# Altium schematic sheet 03_USB_TO_UART.SchDoc
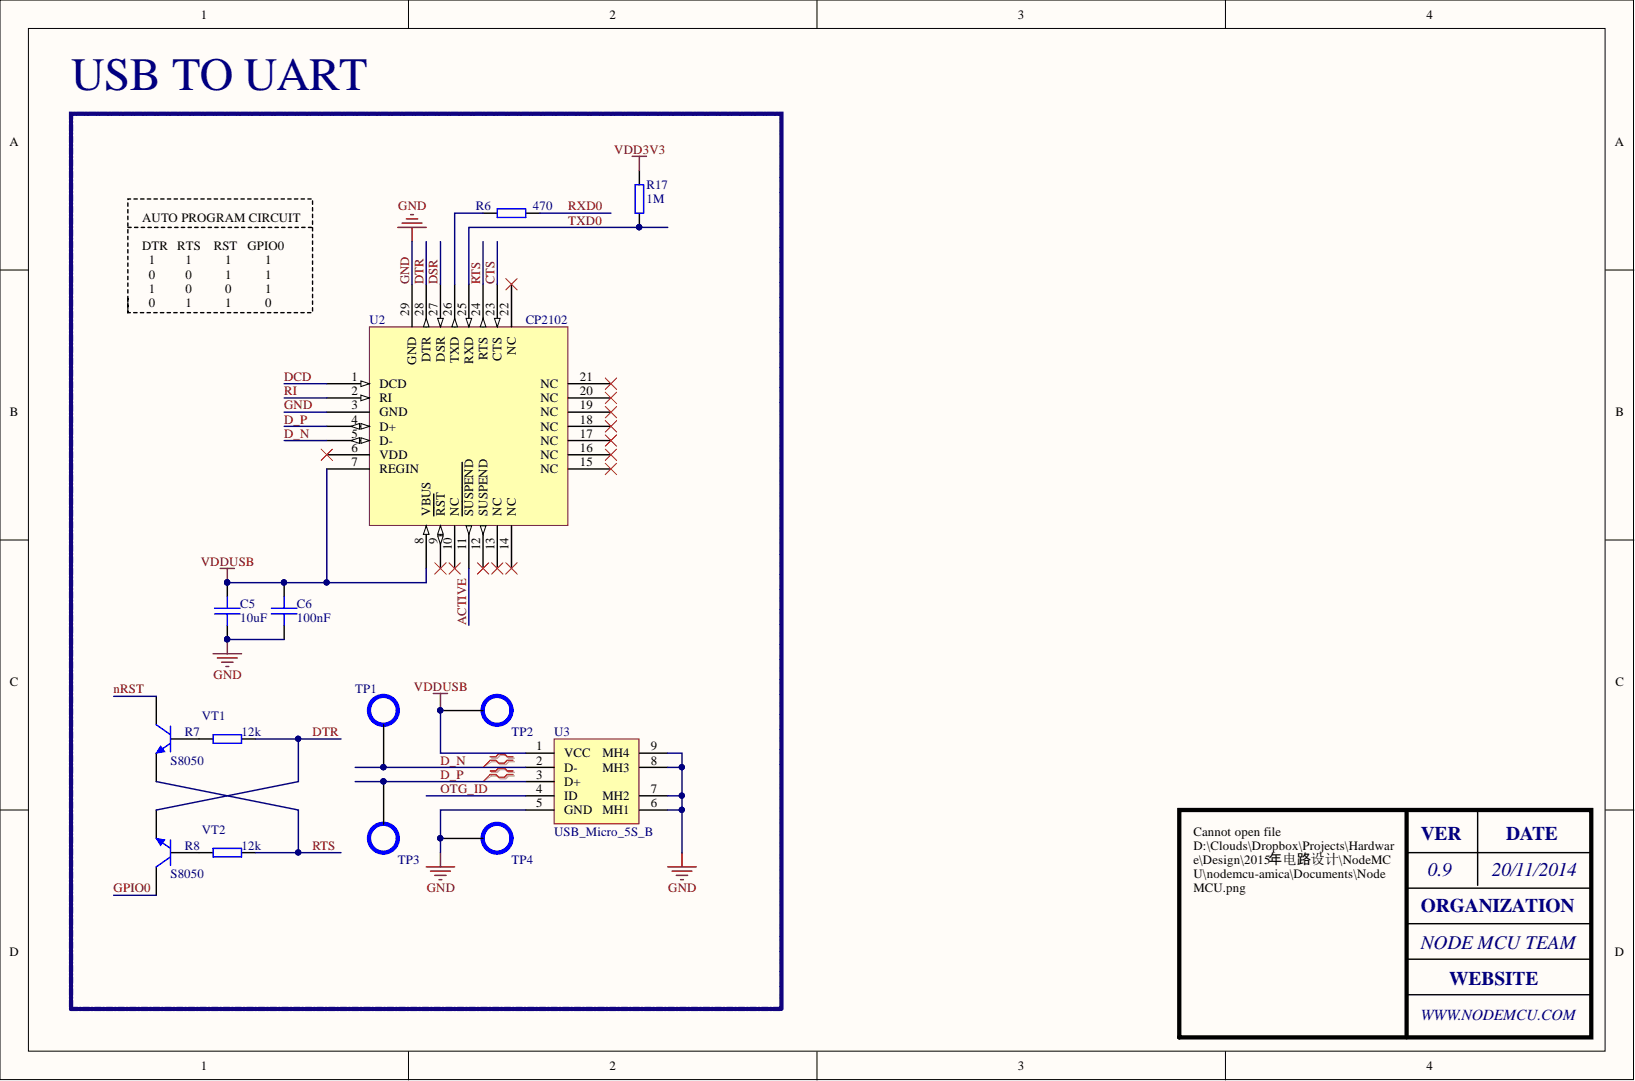
[diagram p0: 03_USB_TO_UART.SchDoc]

## Parts
C5  10uF  [SMT_C_0402]
C6  100nF  [SMT_C_0402]
R6  470  [SMT_R_0402]
R7  12k  [SMT_R_0402]
R8  12k  [SMT_R_0402]
R17  1M  [SMT_R_0402]
TP1  TEST_POINT  [TEST_POINT]  (value not drawn)
TP2  TEST_POINT  [TEST_POINT]  (value not drawn)
TP3  TEST_POINT  [TEST_POINT]  (value not drawn)
TP4  TEST_POINT  [TEST_POINT]  (value not drawn)
U2  CP2102  [CP2102]
U3  USB_Micro_5S_B  [USB_MICRO_5S_B]
VT1  S8050  [SMT_TRIODE_NPN]
VT2  S8050  [SMT_TRIODE_NPN]

## Nets
VDD3V3: R17.2
VDDUSB: C5.1 C6.1 TP2.1 U2.7(REGIN) U2.8(VBUS) U3.1(VCC)
ACTIVE: U2.11(SUSPEND)
CTS: U2.23(CTS)
D_N: TP1.1 U2.5(D-) U3.2(D-)
D_P: TP3.1 U2.4(D+) U3.3(D+)
DCD: U2.1(DCD)
DSR: U2.27(DSR)
DTR: R7.2 U2.28(DTR) VT2.2
GND: C5.2 C6.2 TP4.1 U2.3(GND) U2.29(GND) U3.5(GND) U3.6(MH1) U3.7(MH2) U3.8(MH3) U3.9(MH4)
GPIO0: VT2.3
NRST: VT1.3
OTG_ID: U3.4(ID)
RI: U2.2(RI)
RTS: R8.2 U2.24(RTS) VT1.2
RXD0: R6.2
TXD0: R17.1 U2.25(RXD)
NetR6_1: R6.1 U2.26(TXD)
NetR7_1: R7.1 VT1.1
NetR8_1: R8.1 VT2.1

Not connected: U2 6(VDD) 9(RST) 10(NC) 12(SUSPEND) 13(NC) 14(NC) 15(NC) 16(NC) 17(NC) 18(NC) 19(NC) 20(NC) 21(NC) 22(NC)

## Library data of the parts
C5 C6 = SMT_C_0402: Surface mount capacitor 0402; Component Kind: Standard; Library Name: Vowstar_Basic_Device.IntLib
R6-R8 R17 = SMT_R_0402: Surface mount resistor 0402; Component Kind: Standard; Library Name: Vowstar_Basic_Device.IntLib
TP1-TP4 = TEST_POINT: Test point; Component Kind: Standard; Library Name: koruza-compute-module-board.IntLib
U3 = USB_Micro_5S_B: USB micro female SMT with 4 fixed foot; Component Kind: Standard; Library Name: Vowstar_Connector.IntLib
VT1 VT2 = SMT_TRIODE_NPN: Surface mount NPN transistor, package SOT-23; Component Kind: Standard; Library Name: Vowstar_Basic_Device.IntLib
U2 = CP2102: Component Kind: Standard; Description: CP2102; Library Name: Vowstar_IC_General.IntLib
